# Altium schematic sheet GammaMRICAB_2 - Connectors2.SchDoc
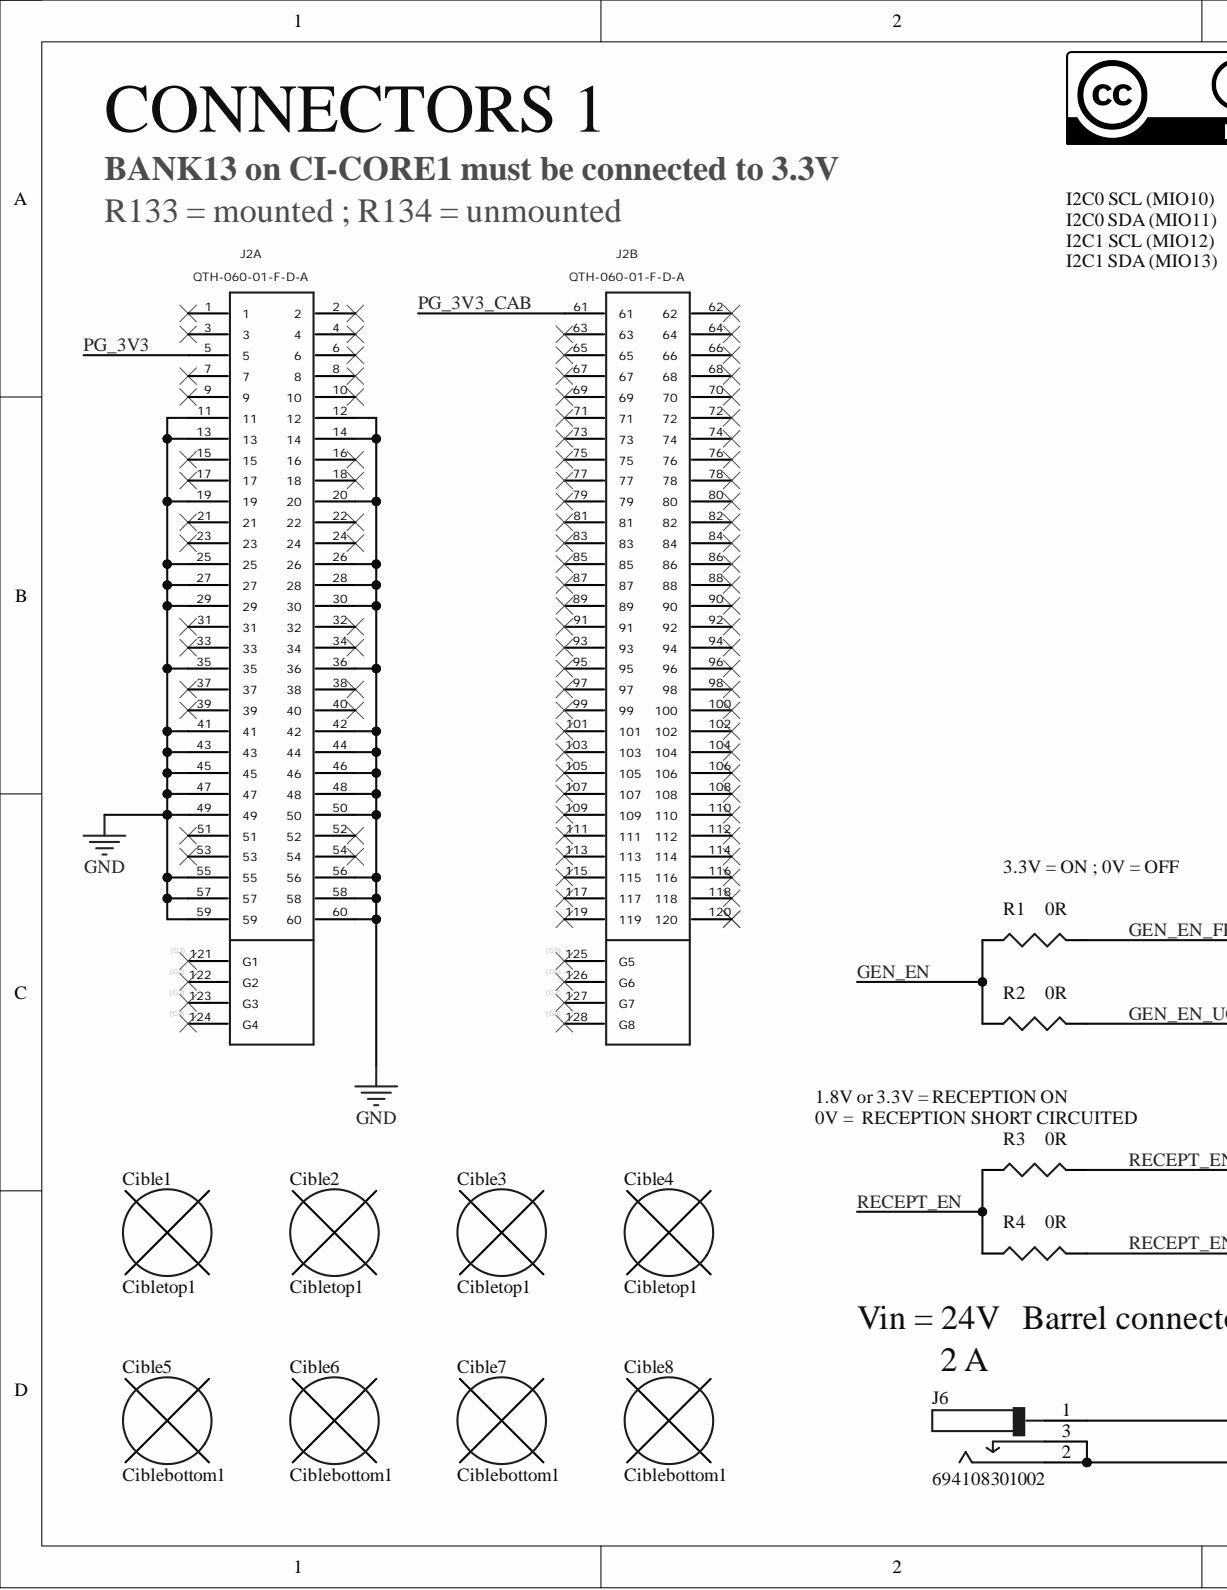
[diagram p0: GammaMRICAB_2 - Connectors2.SchDoc, left]
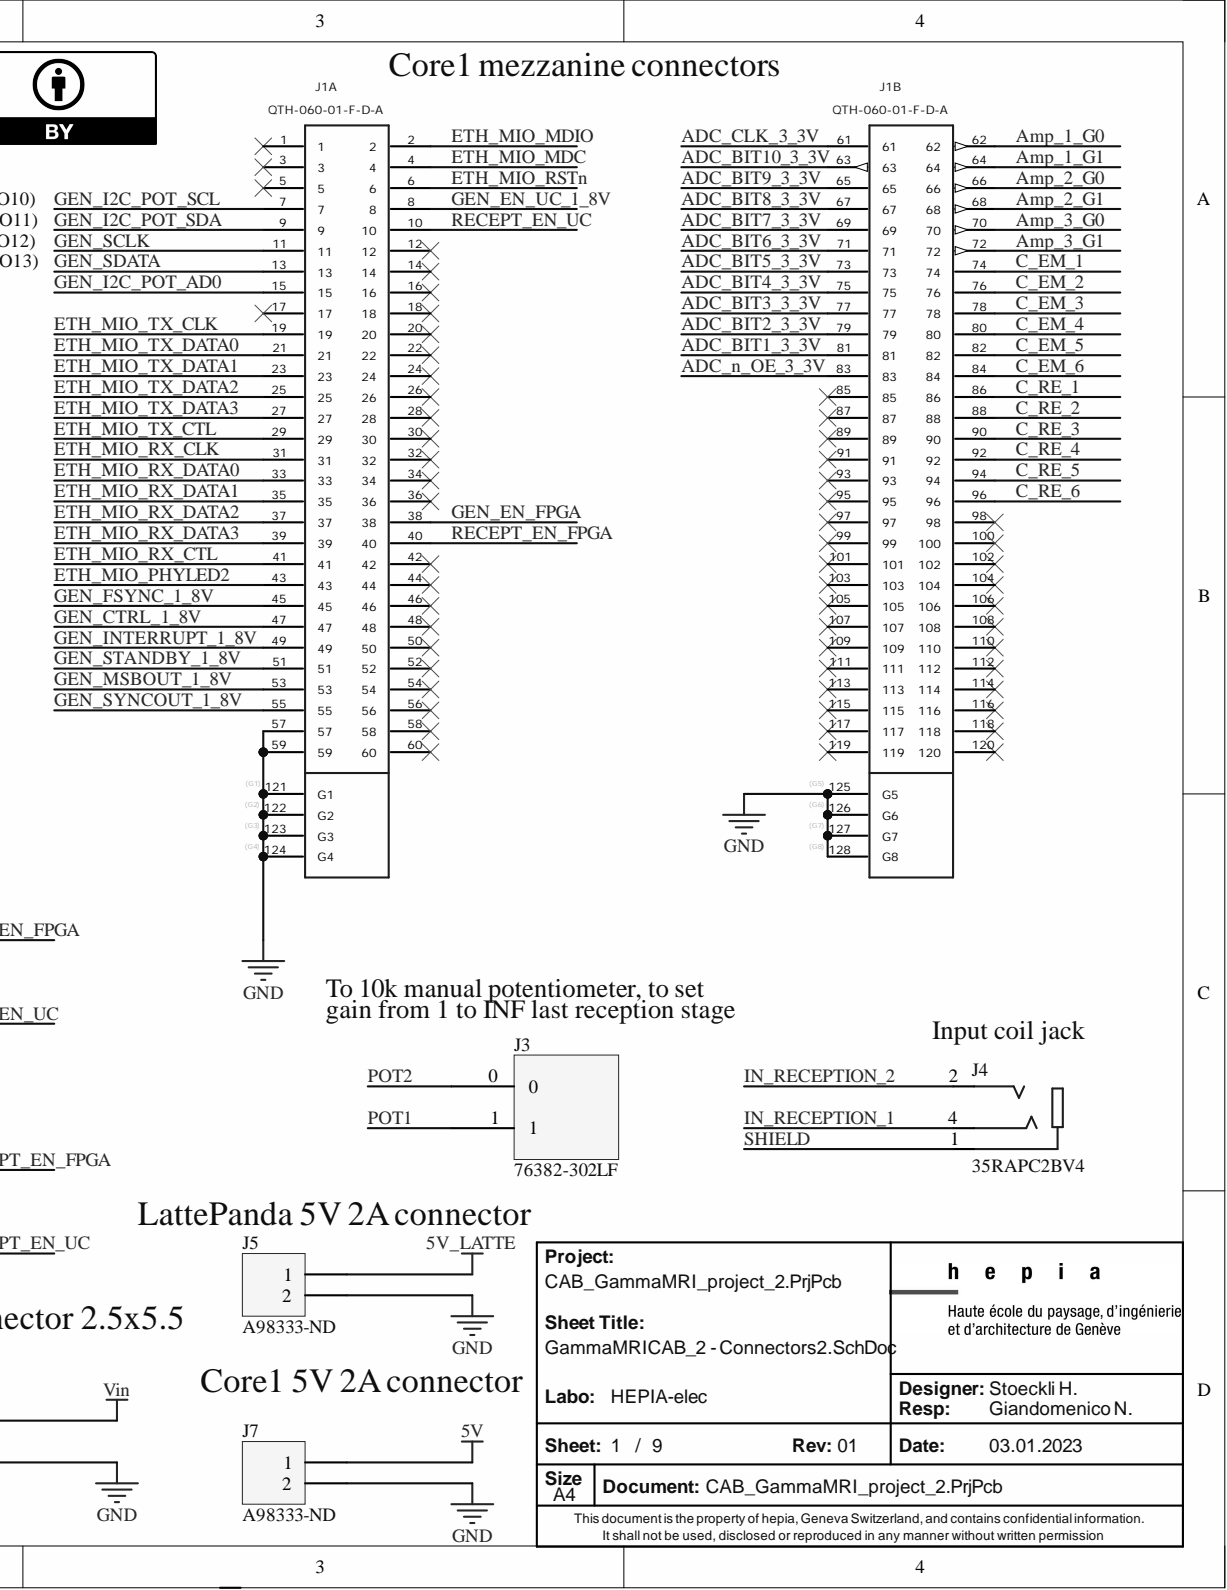
[diagram p1: GammaMRICAB_2 - Connectors2.SchDoc, right]

## Parts
Cible1  Cibletop1  [Footprintcible]
Cible2  Cibletop1  [Footprintcible]
Cible3  Cibletop1  [Footprintcible]
Cible4  Cibletop1  [Footprintcible]
Cible5  Ciblebottom1  [Footprintcible]
Cible6  Ciblebottom1  [Footprintcible]
Cible7  Ciblebottom1  [Footprintcible]
Cible8  Ciblebottom1  [Footprintcible]
J1  QTH-060-01-F-D-A  [QTH-060-XX-X-D-A]  (parts A,B)
J2  QTH-060-01-F-D-A  [QTH-060-XX-X-D-A]  (parts A,B)
J3  76382-302LF  [76382-302LF]
J4  35RAPC2BV4  [SWITCHCRAFT_35RAPC2BV4]
J5  A98333-ND  [Bornier-Vis_2P_P2.54_90]
J6  694108301002  [FP-694108301002-MFG]
J7  A98333-ND  [Bornier-Vis_2P_P2.54_90]
R1  0R  [0402]
R2  0R  [0402]
R3  0R  [0402]
R4  0R  [0402]

## Nets
5V: J7.1
5V_LATTE: J5.1
GND: J1.57 J1.59 J1.G1 J1.G2 J1.G3 J1.G4 J1.G5 J1.G6 J1.G7 J1.G8 J2.11 J2.12 J2.13 J2.14 J2.19 J2.20 J2.25 J2.26 J2.27 J2.28 J2.29 J2.30 J2.35 J2.36 J2.41 J2.42 J2.43 J2.44 J2.45 J2.46 J2.47 J2.48 J2.49 J2.50 J2.55 J2.56 J2.57 J2.58 J2.59 J2.60 J5.2 J6.2 J6.3 J7.2
Vin: J6.1
ADC_BIT1_3_3V: J1.81
ADC_BIT2_3_3V: J1.79
ADC_BIT3_3_3V: J1.77
ADC_BIT4_3_3V: J1.75
ADC_BIT5_3_3V: J1.73
ADC_BIT6_3_3V: J1.71
ADC_BIT7_3_3V: J1.69
ADC_BIT8_3_3V: J1.67
ADC_BIT9_3_3V: J1.65
ADC_BIT10_3_3V: J1.63
ADC_CLK_3_3V: J1.61
ADC_n_OE_3_3V: J1.83
Amp_1_G0: J1.62
Amp_1_G1: J1.64
Amp_2_G0: J1.66
Amp_2_G1: J1.68
Amp_3_G0: J1.70
Amp_3_G1: J1.72
C_EM_1: J1.74
C_EM_2: J1.76
C_EM_3: J1.78
C_EM_4: J1.80
C_EM_5: J1.82
C_EM_6: J1.84
C_RE_1: J1.86
C_RE_2: J1.88
C_RE_3: J1.90
C_RE_4: J1.92
C_RE_5: J1.94
C_RE_6: J1.96
ETH_MIO_MDC: J1.4
ETH_MIO_MDIO: J1.2
ETH_MIO_PHYLED2: J1.43
ETH_MIO_RSTn: J1.6
ETH_MIO_RX_CLK: J1.31
ETH_MIO_RX_CTL: J1.41
ETH_MIO_RX_DATA0: J1.33
ETH_MIO_RX_DATA1: J1.35
ETH_MIO_RX_DATA2: J1.37
ETH_MIO_RX_DATA3: J1.39
ETH_MIO_TX_CLK: J1.19
ETH_MIO_TX_CTL: J1.29
ETH_MIO_TX_DATA0: J1.21
ETH_MIO_TX_DATA1: J1.23
ETH_MIO_TX_DATA2: J1.25
ETH_MIO_TX_DATA3: J1.27
GEN_CTRL_1_8V: J1.47
GEN_EN: R1.1 R2.1
GEN_EN_FPGA: J1.38 R1.2
GEN_EN_UC: R2.2
GEN_EN_UC_1_8V: J1.8
GEN_FSYNC_1_8V: J1.45
GEN_I2C_POT_AD0: J1.15
GEN_I2C_POT_SCL: J1.7
GEN_I2C_POT_SDA: J1.9
GEN_INTERRUPT_1_8V: J1.49
GEN_MSBOUT_1_8V: J1.53
GEN_SCLK: J1.11
GEN_SDATA: J1.13
GEN_STANDBY_1_8V: J1.51
GEN_SYNCOUT_1_8V: J1.55
IN_RECEPTION_1: J4.4
IN_RECEPTION_2: J4.2
PG_3V3: J2.5
PG_3V3_CAB: J2.61
POT1: J3.1
POT2: J3.0
RECEPT_EN: R3.1 R4.1
RECEPT_EN_FPGA: J1.40 R3.2
RECEPT_EN_UC: J1.10 R4.2
SHIELD: J4.1

Not connected: J1 1 3 5 12 14 16 17 18 20 22 24 26 28 30 32 34 36 42 44 46 48 50 52 54 56 58 60 85 87 89 91 93 95 97 98 99 100 101 102 103 104 105 106 107 108 109 110 111 112 113 114 115 116 117 118 119 120; J2 1 2 3 4 6 7 8 9 10 15 16 17 18 21 22 23 24 31 32 33 34 37 38 39 40 51 52 53 54 62 63 64 65 66 67 68 69 70 71 72 73 74 75 76 77 78 79 80 81 82 83 84 85 86 87 88 89 90 91 92 93 94 95 96 97 98 99 100 101 102 103 104 105 106 107 108 109 110 111 112 113 114 115 116 117 118 119 120 G1 G2 G3 G4 G5 G6 G7 G8

## Library data of the parts
Cible1-Cible8 = Cible: Component Kind: Standard; Library Name: Schlib1.SchLib
J1 J2 = QTH-060-01-X-D-A: HIGH SPEED TERMINAL STRIP, .5mm PITCH, 120 PINS.; Component Kind: Standard; Library Name: QTH-060-01-X-D-A--Symbol-Altium-44987.IntLib; Supplier1: Digikey; Supplier1PN1: SAM10669-ND
J3 = 76382-302LF: 2 PIN connector; Component Kind: Standard; Supplier1: Digikey; Supplier1PN1: 609-1278-ND; Library Name: CAB_SCH_LIB.SchLib
J4 = 35RAPC2BV4: 3.50mm (0.141, 1/8, Mini Plug) - Headphone Phone Jack Stereo (3 Conductor, TRS) Connector Solder; Component Kind: Standard; Supplier1: Digikey; Supplier1PN1: SC1460-ND; Library Name: CAB_SCH_LIB.SchLib
J5 J7 = Header 2: TERM BLK 2P SIDE ENT 2.54MM PCB; Component Kind: Standard; Library Name: Miscellaneous Connectors.IntLib; Signal Integrity: Connector; Supplier1: Digikey; Supplier1PN1: A98333-ND
J6 = CMP-1502-00190-2: CONN PWR JACK 2.5X5.5MM SOLDER; Component Kind: Standard; Library Name: Altium Content Vault; Supplier1: Digikey; Supplier1PN1: 732-5934-ND
R1-R4 = Res3: Resistor 0Ohm 0402; Component Kind: Standard; Library Name: Miscellaneous Devices.IntLib; Signal Integrity: Res; Simulation: RESISTOR; Supplier1: Digikey; Supplier1PN1: RMCF0402ZT0R00CT-ND‎
